# Altium schematic sheet Schematic.SchDoc(Schematic)
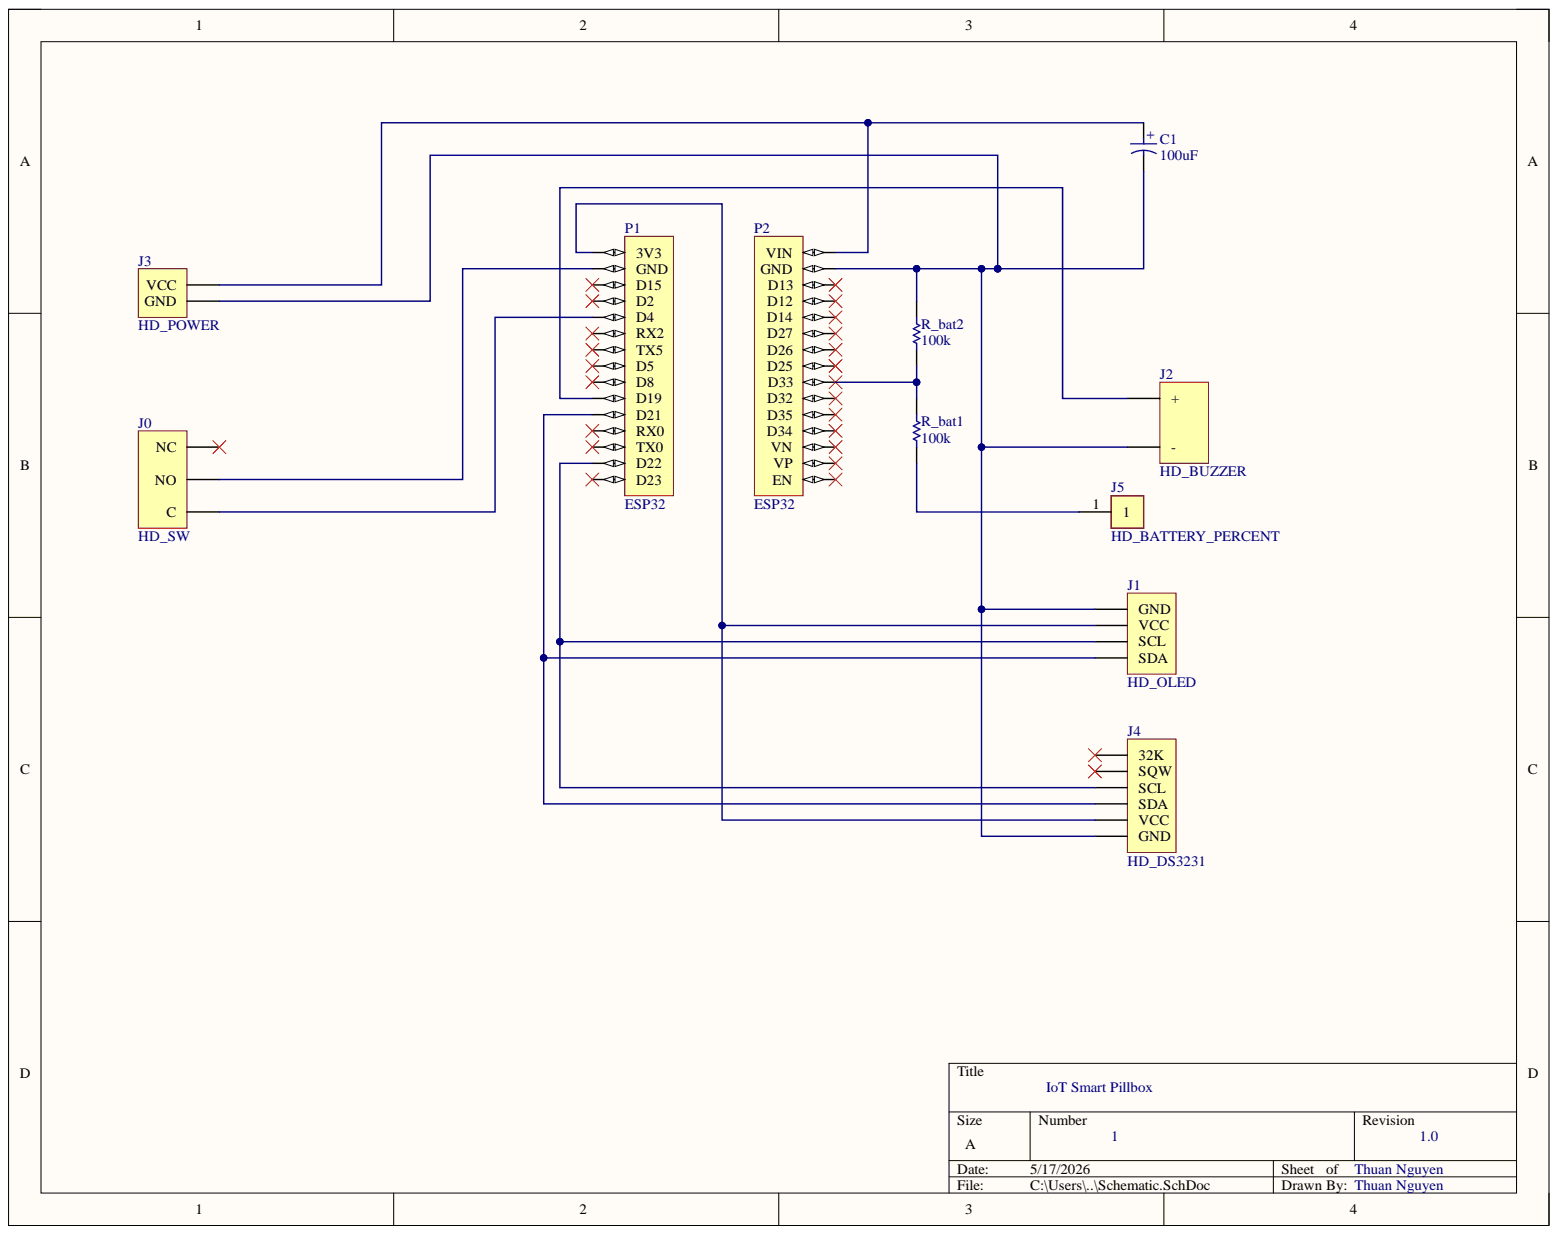
[diagram p0: Schematic.SchDoc]

## Parts
C1  100uF  [WCAP-ATLI_D6.3H11]
J0  HD_SW  [HDR1X5]
J1  HD_OLED  [HDR1X4]
J2  HD_BUZZER  [HDR1X4]
J3  HD_POWER  [HDR1X2]
J4  HD_DS3231  [HDR1X6]
J5  HD_BATTERY_PERCENT  [FP-5000-MFG]
P1  ESP32  [HDR1X15]
P2  ESP32  [HDR1X15]
R_bat1  100k  [RESA60-630X240]
R_bat2  100k  [RESA60-630X240]

## Nets
NetC1_1: C1.1 J3.1 P2.15(VIN)
NetC1_2: C1.2 J1.1(GND) J2.4 J3.2 J4.6(GND) P2.14(GND) R_bat2.2
NetJ0_3: J0.3 P1.2(GND)
NetJ0_5: J0.5 P1.5(D4)
NetJ1_2: J1.2(VCC) J4.5(VCC) P1.1(3V3)
NetJ1_3: J1.3(SCL) J4.3(SCL) P1.14(D22)
NetJ1_4: J1.4(SDA) J4.4(SDA) P1.11(D21)
NetJ2_1: J2.1 P1.10(D19)
NetJ5_1: J5.1 R_bat1.1
NetP2_7: P2.7(D33) R_bat1.2 R_bat2.1

Not connected: J0 1; J4 1(32K) 2(SQW); P1 3(D15) 4(D2) 6(RX2) 7(TX5) 8(D5) 9(D8) 12(RX0) 13(TX0) 15(D23); P2 1(EN) 2(VP) 3(VN) 4(D34) 5(D35) 6(D32) 8(D25) 9(D26) 10(D27) 11(D14) 12(D12) 13(D13)

## Library data of the parts
C1 = CMP-03913-53914350-1: 860080373005 WCAP-ATLI Aluminum Electrolytic Capacitors; Capacitance: 100µF; Impedance: 250mΩ; Leakage Current: 16µA; Life (Hours): 2000hours; Material: Aluminium; Pitch: 2.5mm; Polarity: Polar; Ripple Current: 360mA; Tolerance: 20%; Voltage Rating: 16V; Case/Package: Radial; Component Kind: Standard; Diameter: 6.3mm; Height: 11mm; Height - Seated (Max): 12.4968mm; Lead Pitch: 2.4892mm; Length: 11mm; Library Name: Altium Content Vault; Max Operating Temperature: 105°C; Min Operating Temperature: -55°C; Mount: Through Hole; NexarPartId: 53914350; Packaging: Tape & Box (TB); RoHS: Compliant
J0 = Header 5: Header, 5-Pin; Component Kind: Standard; LatestRevisionDate: 17-Jul-2002; LatestRevisionNote: Re-released for DXP Platform.; Library Name: Miscellaneous Connectors.IntLib; Signal Integrity: Connector; Publisher: Altium Limited
J1 J2 = Header 4: Header, 4-Pin; Component Kind: Standard; LatestRevisionDate: 17-Jul-2002; LatestRevisionNote: Re-released for DXP Platform.; Library Name: Miscellaneous Connectors.IntLib; Signal Integrity: Connector; Publisher: Altium Limited
J3 = Header 2: Header, 2-Pin; Component Kind: Standard; LatestRevisionDate: 17-Jul-2002; LatestRevisionNote: Re-released for DXP Platform.; Library Name: Miscellaneous Connectors.IntLib; Signal Integrity: Connector; Publisher: Altium Limited
J4 = Header 6: Header, 6-Pin; Component Kind: Standard; LatestRevisionDate: 17-Jul-2002; LatestRevisionNote: Re-released for DXP Platform.; Library Name: Miscellaneous Connectors.IntLib; Signal Integrity: Connector; Publisher: Altium Limited
J5 = CMP-19636-000027-1: PC TEST POINT MINIATURE RED; Body Material: Bronze; Hole Diameter: 1.6mm; Inside Diameter: 1.27mm; Insulation: Insulated; Number of Contacts: 1; Outside Diameter: 2.54mm; Plating: Silver; Color: Red; Component Kind: Standard; Contact Material: Bronze; Contact Plating: Tin; Flammability Rating: UL94 V-0; Height: 0.3inch; Housing Color: Red; Lead Free: Lead Free; Length: 7.62mm; Library Name: Altium Content Vault; Material: Nylon; Mount: PCB; Number of Ports: 1; Orientation: Straight; Package Quantity: 100; Packaging: Bulk; REACH SVHC: No SVHC; RoHS Compliant: Yes; Series: THM
P1 P2 = Header 15: Header, 15-Pin; Component Kind: Standard; LatestRevisionDate: 17-Jul-2002; LatestRevisionNote: Re-released for DXP Platform.; Library Name: Miscellaneous Connectors.IntLib; Signal Integrity: Connector; Publisher: Altium Limited
R_bat1 R_bat2 = CMP-1659-00073-1: Axial Resistor, 100 KOhm, +/- 1%, 0.25 W, -55 to 155 degC, 2-Pin THD, RoHS, Bulk; Power Rating: 250 mW; Resistance: 475 Ohm; Voltage Rating: 250 V; Case\Package: Axial; Component Kind: Standard; ComponentLink1Description: Manufacturer URL; ComponentLink1URL: http://www.yageo.com/; ComponentLink2Description: Datasheet; ComponentLink2URL: http://www.yageo.com/documents/recent/Yageo%20LR_MFR_2013.pdf; DatasheetVersion: Apr-13; Length: 0.248 inch; Library Name: Altium Content Vault; Max Operating Temperature: 155 degC; Min Operating Temperature: -55 degC; Mount: Through Hole; Package Quantity: 200; PackageDescription: 2-Pin Axial Lead, Dia 2.4 mm, Body Length 6.3 mm; PackageReference: MFR-25; Packaging: Bulk; RoHSCompliant: true; Tolerance: 1%
